# Altium schematic sheet Control.SchDoc(Control)
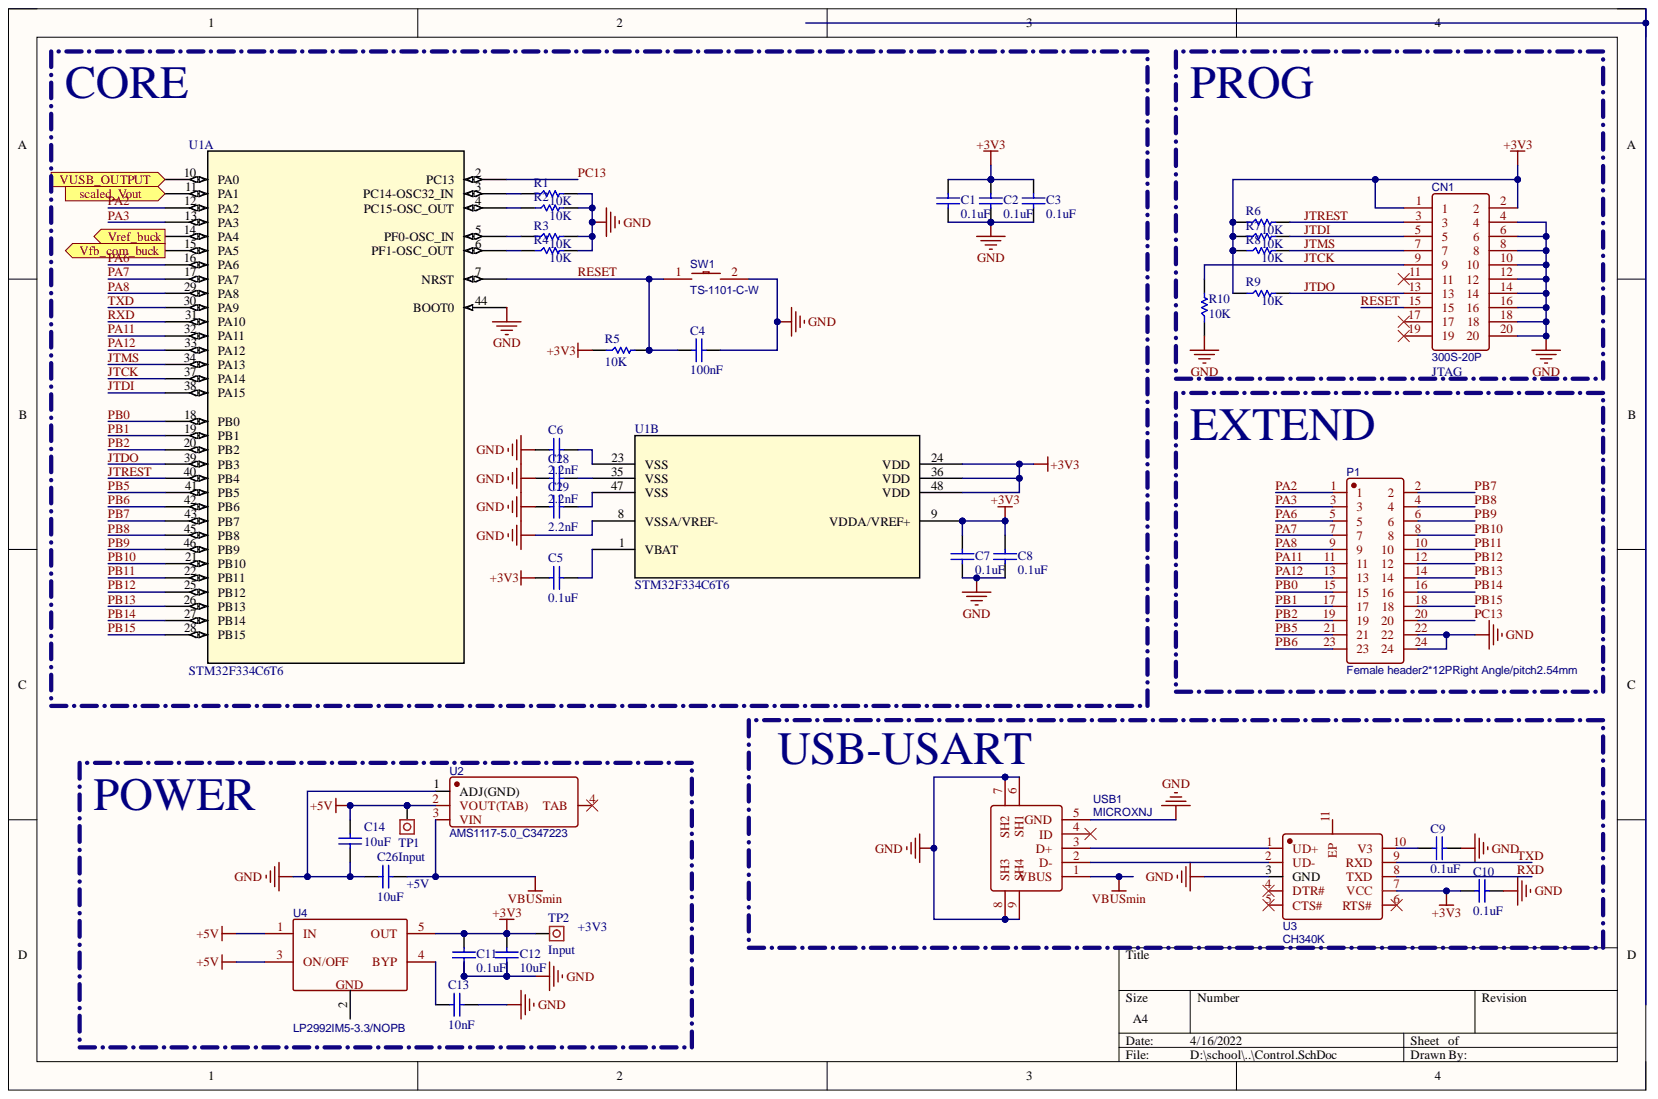
[diagram p0: Control.SchDoc]

## Parts
C1  0.1uF  [0805C]
C2  0.1uF  [0805C]
C3  0.1uF  [0805C]
C4  100nF  [0805C]
C5  0.1uF  [0805C]
C6  2.2nF  [0805C]
C7  0.1uF  [0805C]
C8  0.1uF  [0805C]
C9  0.1uF  [0805C]
C10  0.1uF  [0805C]
C11  0.1uF  [0805C]
C12  10uF  [0805C]
C13  10nF  [0805C]
C14  10uF  [0805C]
C26  10uF  [0805C]
C28  2.2nF  [0805C]
C29  2.2nF  [0805C]
CN1  300S-20P  [CONN-TH_300S-20P]
P1  Female header2*12PRight Angle/pitch2.54mm  [HDR-TH_24P-P2.54-V-F-R2-C12-S2.54]
R1  10K  [0805]
R2  10K  [0805]
R3  10K  [0805]
R4  10K  [0805]
R5  10K  [0805]
R6  10K  [0805]
R7  10K  [0805]
R8  10K  [0805]
R9  10K  [0805]
R10  10K  [0805]
SW1  TS-1101-C-W  [SW-SMD_L6.0-W3.3-LS8.0]
TP1  INPUT  [Testpoin]
TP2  INPUT  [Testpoin]
U1  STM32F334C6T6  [LQFP48-7x7mm]  (parts A,B)
U2  AMS1117-5.0_C347223  [SOT-223_L6.7-W3.5-P2.30-BR]
U3  CH340K  [ESOP-10_L4.9-W3.9-P1.00-LS6.2-BL-EP]
U4  LP2992IM5-3.3/NOPB  [SOT-23-5_L3.0-W1.7-P0.95-LS2.8-BR]
USB1  MICROXNJ  [MICRO-USB-SMD_MICROXNJ]

## Ports
scaled_Vout, Vfb_com_buck, Vref_buck, VUSB_OUTPUT

## Nets
+3V3: C1.1 C2.1 C3.1 C5.2 C7.1 C8.1 C10.2 C11.1 C12.1 CN1.1 CN1.2 R5.1 R6.1 R7.1 R8.1 R9.1 TP2.1 U1.9(VDDA/VREF+) U1.24(VDD) U1.36(VDD) U1.48(VDD) U3.7(VCC) U4.5(OUT)
+5V: C14.1 TP1.1 U2.2(VOUT(TAB)) U4.1(IN) U4.3(ON/OFF)
GND: C1.2 C2.2 C3.2 C4.1 C6.2 C7.2 C8.2 C9.2 C10.1 C11.2 C12.2 C13.1 C14.2 C26.2 C28.2 C29.2 CN1.4 CN1.6 CN1.8 CN1.10 CN1.12 CN1.14 CN1.16 CN1.18 CN1.20 P1.22 P1.24 R1.2 R2.2 R3.2 R4.2 R10.1 SW1.2 U1.8(VSSA/VREF-) U1.44(BOOT0) U2.1(ADJ(GND)) U3.3(GND) USB1.5(GND) USB1.6(SH1) USB1.7(SH2) USB1.8(SH3) USB1.9(SH4)
VBUSmin: C26.1 U2.3(VIN) USB1.1(VBUS)
JTCK: CN1.9 R10.2 U1.37(PA14)
JTDI: CN1.5 R7.2 U1.38(PA15)
JTDO: CN1.13 R9.2 U1.39(PB3)
JTMS: CN1.7 R8.2 U1.34(PA13)
JTREST: CN1.3 R6.2 U1.40(PB4)
PA2: P1.1 U1.12(PA2)
PA3: P1.3 U1.13(PA3)
PA6: P1.5 U1.16(PA6)
PA7: P1.7 U1.17(PA7)
PA8: P1.9 U1.29(PA8)
PA11: P1.11 U1.32(PA11)
PA12: P1.13 U1.33(PA12)
PB0: P1.15 U1.18(PB0)
PB1: P1.17 U1.19(PB1)
PB2: P1.19 U1.20(PB2)
PB5: P1.21 U1.41(PB5)
PB6: P1.23 U1.42(PB6)
PB7: P1.2 U1.43(PB7)
PB8: P1.4 U1.45(PB8)
PB9: P1.6 U1.46(PB9)
PB10: P1.8 U1.21(PB10)
PB11: P1.10 U1.22(PB11)
PB12: P1.12 U1.25(PB12)
PB13: P1.14 U1.26(PB13)
PB14: P1.16 U1.27(PB14)
PB15: P1.18 U1.28(PB15)
PC13: P1.20 U1.2(PC13)
RESET: C4.2 CN1.15 R5.2 SW1.1 U1.7(NRST)
RXD: U1.31(PA10) U3.8(TXD)
scaled_Vout: U1.11(PA1) ; port scaled_Vout
TXD: U1.30(PA9) U3.9(RXD)
Vfb_com_buck: U1.15(PA5) ; port Vfb_com_buck
Vref_buck: U1.14(PA4) ; port Vref_buck
VUSB_OUTPUT: U1.10(PA0) ; port VUSB_OUTPUT
NetC5_1: C5.1 U1.1(VBAT)
NetC6_1: C6.1 U1.23(VSS)
NetC9_1: C9.1 U3.10(V3)
NetC13_2: C13.2 U4.4(BYP)
NetC28_1: C28.1 U1.35(VSS)
NetC29_1: C29.1 U1.47(VSS)
NetR1_1: R1.1 U1.3(PC14-OSC32_IN)
NetR2_1: R2.1 U1.4(PC15-OSC_OUT)
NetR3_1: R3.1 U1.5(PF0-OSC_IN)
NetR4_1: R4.1 U1.6(PF1-OSC_OUT)
NetU3_1: U3.1(UD+) USB1.3(D+)
NetU3_2: U3.2(UD-) USB1.2(D-)

Not connected: CN1 11 17 19; U2 4(TAB); U3 4(DTR#) 5(CTS#) 6(RTS#) 11(EP); U4 2; USB1 4(ID)

## Library data of the parts
C1-C3 C5 C7-C11 = C_0.1uf: Capacitor; Reviewed by: RM - 2018/08/05; Component Kind: Standard; Revision: July-2002: Re-released for DXP Platform.; Simulation: CAP; Value: 0.1uF; Library Name: Portable power supply.IntLib
C4 = C_100nf: Capacitor; Reviewed by: RM - 2018/08/05; Component Kind: Standard; Revision: July-2002: Re-released for DXP Platform.; Simulation: CAP; Value: 100nF; Library Name: Portable power supply.IntLib
C6 C28 C29 = C_2.2nF: Capacitor; Reviewed by: RM - 2018/08/05; Component Kind: Standard; Revision: July-2002: Re-released for DXP Platform.; Simulation: CAP; Value: 2.2nF; Library Name: Portable power supply.IntLib
C12 C14 C26 = C_10uf: Capacitor; Reviewed by: RM - 2018/08/05; Component Kind: Standard; Revision: July-2002: Re-released for DXP Platform.; Simulation: CAP; Value: 10uF; Library Name: Portable power supply.IntLib
C13 = C_10nF: Capacitor; Reviewed by: RM - 2018/08/05; Component Kind: Standard; Revision: July-2002: Re-released for DXP Platform.; Simulation: CAP; Value: 10nF; Library Name: Portable power supply.IntLib
CN1 = 300S-20P: BOM_Manufacturer: Shenzhen Cankemeng; Contributor: LCSC; BOM_JLCPCB Part Class: Extended Part; BOM_Manufacturer Part: -; BOM_Supplier: LCSC; BOM_Supplier Part: C132442; Component Kind: Standard; spicePre: C; spiceSymbolName: 300S-20P; Library Name: Portable power supply.IntLib
P1 = Female header2*12PRight Angle/pitch2.54mm: BOM_Manufacturer: BOOMELE; BOM_JLCPCB Part Class: Extended Part; BOM_Manufacturer Part: Female header2*12PRight Angle/pitch2.54mm; BOM_Supplier: LCSC; BOM_Supplier Part: C62744; Component Kind: Standard; spicePre: P; spiceSymbolName: Female header2*12PRight Angle/pitch2.54mm; Library Name: Portable power supply.IntLib
R1-R10 = R_10k: Resistor; Reviewed by: RM - 2018/08/05; Component Kind: Standard; Simulation: RES; Value: 10K; Library Name: Portable power supply.IntLib
SW1 = TS-1101-C-W: BOM_Manufacturer: XKB Connectivity(中国星坤); Contributor: LCSC; BOM_JLCPCB Part Class: Extended Part; BOM_Manufacturer Part: TS-1101-C-W; BOM_Supplier: LCSC; BOM_Supplier Part: C318938; Component Kind: Standard; spicePre: S; spiceSymbolName: TS-1101-C-W; Library Name: Portable power supply.IntLib
TP1 TP2 = Test Point: Pad; Component Kind: Standard; Value: Input; Library Name: Portable power supply.IntLib
U1 = STM32F334C6T6: No Description Available; Mfr_Name: STMicroelectronics; Component Kind: Standard; Manufacturer_Part_Number: STM32F334C6T6; RefDes: RefDes; Type: DEV; Copyright: Copyright (C) 2021 Ultra Librarian. All rights reserved.; Library Name: Portable power supply.IntLib
U2 = AMS1117-5.0_C347223: BOM_Manufacturer: Youtai Semiconductor Co., Ltd.; Contributor: LCSC; BOM_JLCPCB Part Class: Extended Part; BOM_Manufacturer Part: AMS1117-5.0; BOM_Supplier: LCSC; BOM_Supplier Part: C347223; Component Kind: Standard; spicePre: U; spiceSymbolName: AMS1117-5.0_C347223; Library Name: Portable power supply.IntLib
U3 = CH340K: BOM_Manufacturer: WCH(Jiangsu Qin Heng); Contributor: LCSC; BOM_JLCPCB Part Class: Extended Part; BOM_Manufacturer Part: CH340K; BOM_Supplier: LCSC; BOM_Supplier Part: C968586; Component Kind: Standard; spicePre: U; spiceSymbolName: CH340K; Library Name: Portable power supply.IntLib
U4 = LP2992IM5-3.3/NOPB: BOM_Manufacturer: TI; Contributor: LCSC; BOM_JLCPCB Part Class: Extended Part; BOM_Manufacturer Part: LP2992IM5-3.3/NOPB; BOM_Supplier: LCSC; BOM_Supplier Part: C129353; Component Kind: Standard; spicePre: U; spiceSymbolName: LP2992IM5-3.3/NOPB; Library Name: Portable power supply.IntLib
USB1 = MICROXNJ: BOM_Manufacturer: SHOU HAN; Contributor: LCSC; BOM_JLCPCB Part Class: Extended Part; BOM_Manufacturer Part: MicroXNJ; BOM_Supplier: LCSC; BOM_Supplier Part: C404969; Component Kind: Standard; spicePre: U; spiceSymbolName: MICROXNJ; Library Name: Portable power supply.IntLib
